# Altium schematic sheet IMX7S_DEBUG.SchDoc
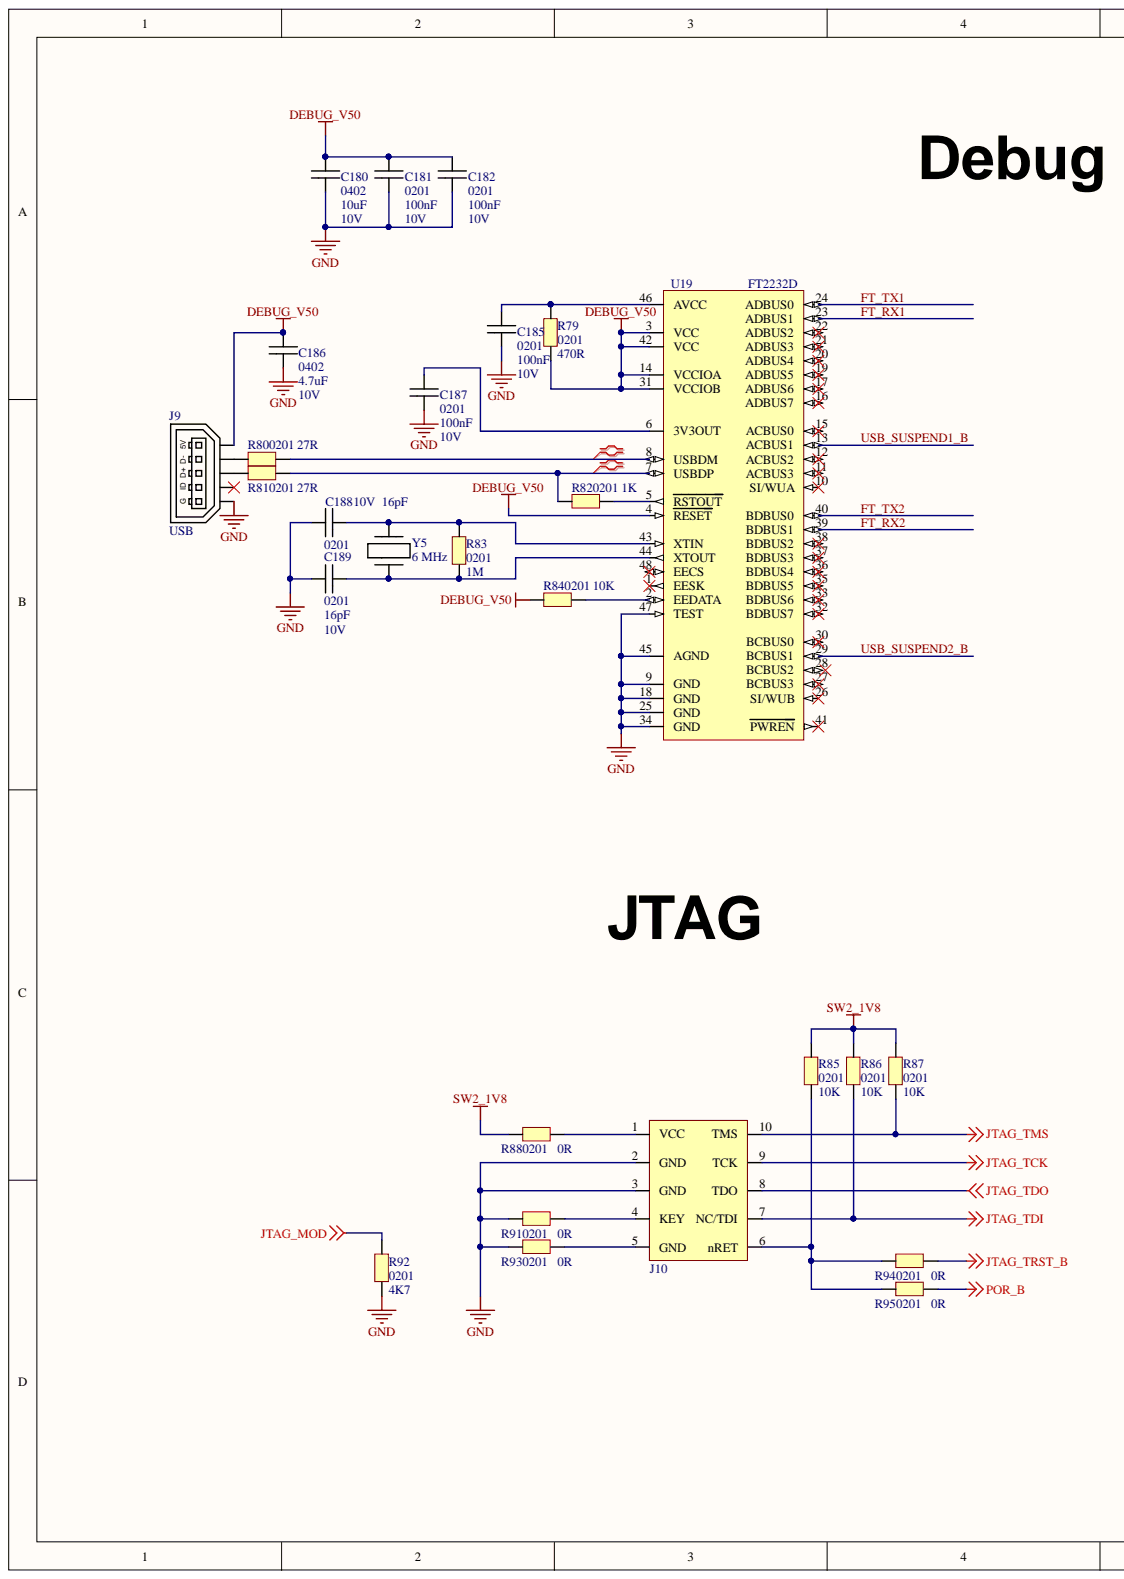
[diagram p0: IMX7S_DEBUG.SchDoc, left]
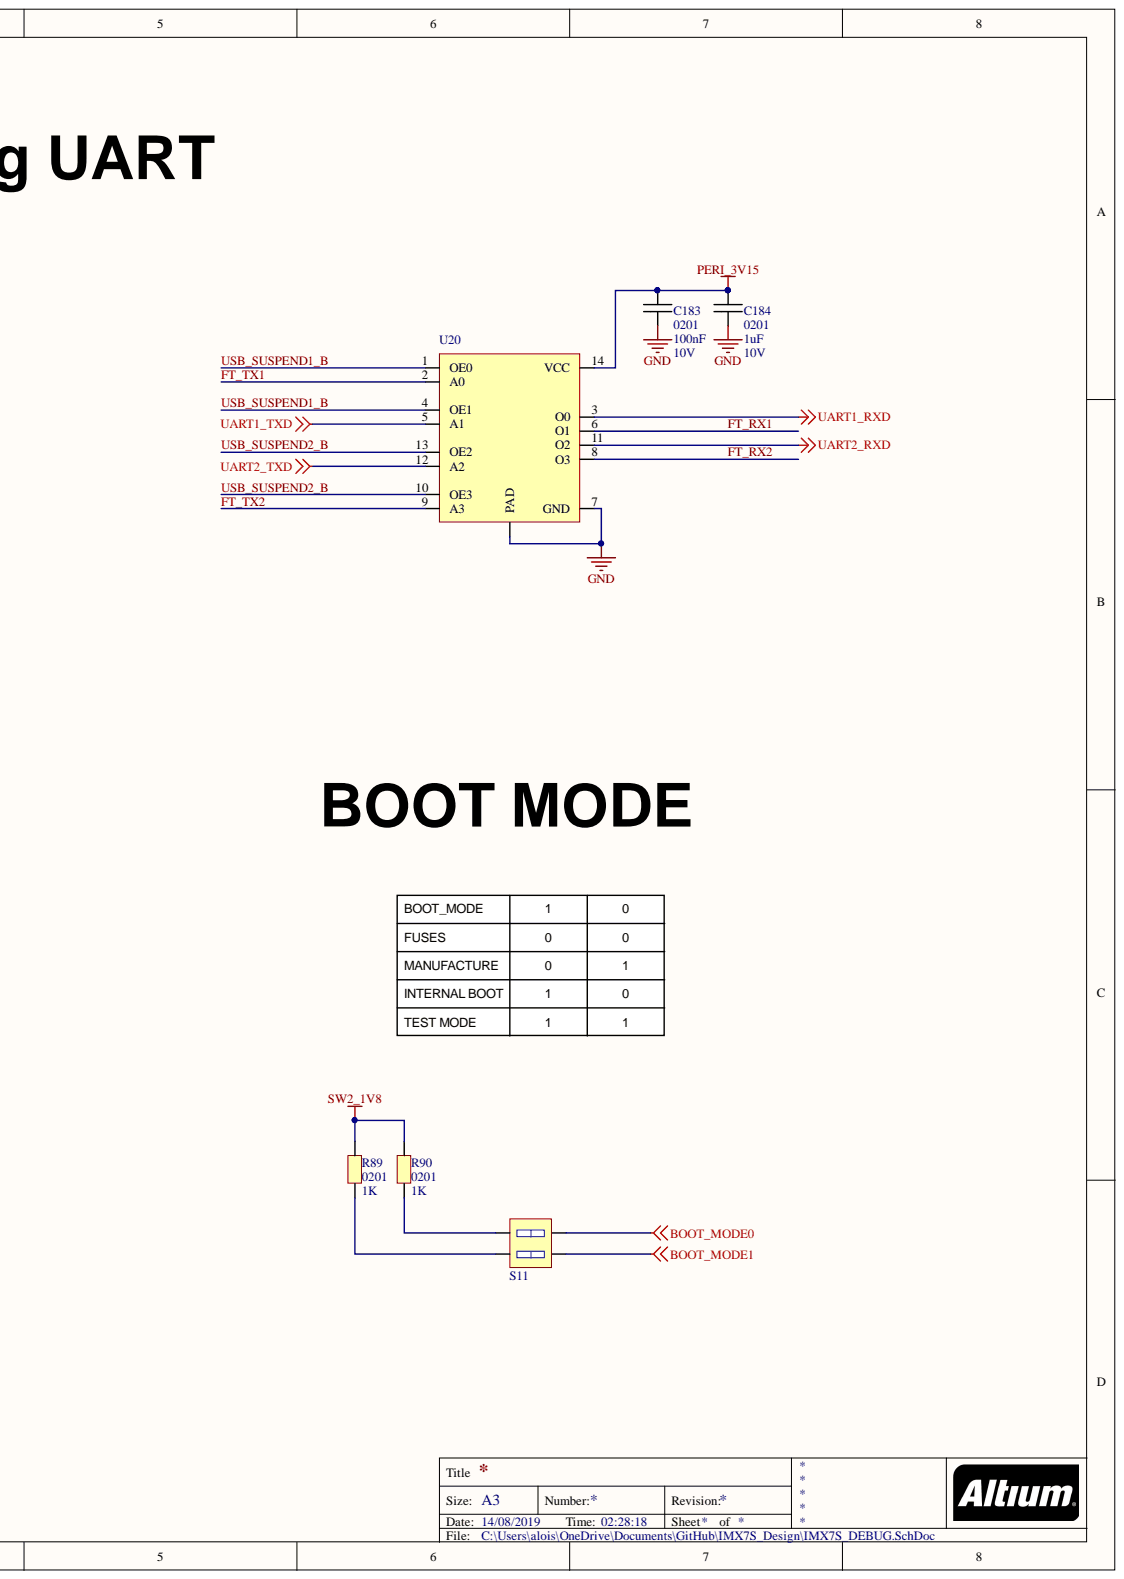
[diagram p1: IMX7S_DEBUG.SchDoc, right]

## Parts
C180  value 10uF  PACKAGE: 0402  VOLTAGE: 10V  [C0402]  (hidden comment: C0402)
C181  value 100nF  PACKAGE: 0201  VOLTAGE: 10V  [C0201]  (hidden comment: C0201)
C182  value 100nF  PACKAGE: 0201  VOLTAGE: 10V  [C0201]  (hidden comment: C0201)
C183  value 100nF  PACKAGE: 0201  VOLTAGE: 10V  [C0201]  (hidden comment: C0201)
C184  value 1uF  PACKAGE: 0201  VOLTAGE: 10V  [C0201]  (hidden comment: C0201)
C185  value 100nF  PACKAGE: 0201  [C0201]  (hidden comment: C0201)
C186  value 4.7uF  PACKAGE: 0402  VOLTAGE: 10V  [C0402]  (hidden comment: C0402)
C187  value 100nF  PACKAGE: 0201  VOLTAGE: 10V  [C0201]  (hidden comment: C0201)
C188  value 16pF  PACKAGE: 0201  VOLTAGE: 10V  [C0201]  (hidden comment: C0201)
C189  value 16pF  PACKAGE: 0201  VOLTAGE: 10V  [C0201]  (hidden comment: C0201)
J9  USB  [MICRO_USB]
J10  CONN_JTAG  [JTAG_CONN]  (value not drawn)
R79  R0201  value 470R  PACKAGE: 0201  [R0201]
R80  value 27R  PACKAGE: 0201  [R0201]  (hidden comment: R0201)
R81  value 27R  PACKAGE: 0201  [R0201]  (hidden comment: R0201)
R82  value 1K  PACKAGE: 0201  [R0201]  (hidden comment: R0201)
R83  value 1M  PACKAGE: 0201  [R0201]  (hidden comment: R0201)
R84  value 10K  PACKAGE: 0201  [R0201]  (hidden comment: R0201)
R85  value 10K  PACKAGE: 0201  [R0201]  (hidden comment: R0201)
R86  value 10K  PACKAGE: 0201  [R0201]  (hidden comment: R0201)
R87  value 10K  PACKAGE: 0201  [R0201]  (hidden comment: R0201)
R88  value 0R  PACKAGE: 0201  [R0201]  (hidden comment: R0201)
R89  value 1K  PACKAGE: 0201  [R0201]  (hidden comment: R0201)
R90  value 1K  PACKAGE: 0201  [R0201]  (hidden comment: R0201)
R91  value 0R  PACKAGE: 0201  [R0201]  (hidden comment: R0201)
R92  value 4K7  PACKAGE: 0201  [R0201]  (hidden comment: R0201)
R93  value 0R  PACKAGE: 0201  [R0201]  (hidden comment: R0201)
R94  value 0R  PACKAGE: 0201  [R0201]  (hidden comment: R0201)
R95  value 0R  PACKAGE: 0201  [R0201]  (hidden comment: R0201)
S11  mikroswitch  [DIP_SWITCH]  (value not drawn)
U19  FT2232D  [LQFP48]
U20  74LCX126BQX  [DQFN14]  (value not drawn)
Y5  6 MHz  [HC49]

## Nets
BOOT_MODE0: S11.4
BOOT_MODE1: S11.3
DEBUG_V50: C180.2 C181.2 C182.2 C186.2 J9.1 R79.1 R84.2 U19.3(VCC) U19.4(RESET) U19.14(VCCIOA) U19.31(VCCIOB) U19.42(VCC)
GND: C180.1 C181.1 C182.1 C183.1 C184.1 C185.1 C186.1 C187.1 C188.2 C189.2 J9.5 J10.2(GND) J10.3(GND) R91.2 R92.2 R93.2 U19.9(GND) U19.18(GND) U19.25(GND) U19.34(GND) U19.45(AGND) U19.47(TEST) U20.0(PAD) U20.7(GND)
JTAG_MOD: R92.1
JTAG_TCK: J10.9(TCK)
JTAG_TDI: J10.7(NC/TDI) R86.2
JTAG_TDO: J10.8(TDO)
JTAG_TMS: J10.10(TMS) R87.2
JTAG_TRST_B: R94.1
PERI_3V15: C183.2 C184.2 U20.14(VCC)
POR_B: R95.1
SW2_1V8: R85.2 R86.1 R87.1 R88.2 R89.2 R90.2
UART1_RXD: U20.3(O0)
UART1_TXD: U20.5(A1)
UART2_RXD: U20.11(O2)
UART2_TXD: U20.12(A2)
FT_RX1: U19.23(ADBUS1) U20.6(O1)
FT_RX2: U19.39(BDBUS1) U20.8(O3)
FT_TX1: U19.24(ADBUS0) U20.2(A0)
FT_TX2: U19.40(BDBUS0) U20.9(A3)
USB_SUSPEND1_B: U19.13(ACBUS1) U20.1(OE0) U20.4(OE1)
USB_SUSPEND2_B: U19.29(BCBUS1) U20.10(OE3) U20.13(OE2)
NetC185_2: C185.2 R79.2 U19.46(AVCC)
NetC187_2: C187.2 U19.6(3V3OUT)
NetC188_1: C188.1 R83.1 U19.43(XTIN) Y5.2
NetC189_1: C189.1 R83.2 U19.44(XTOUT) Y5.1
NetJ9_2: J9.2 R80.2
NetJ9_3: J9.3 R81.2
NetJ10_1: J10.1(VCC) R88.1
NetJ10_4: J10.4(KEY) R91.1
NetJ10_5: J10.5(GND) R93.1
NetJ10_6: J10.6(nRET) R85.1 R94.2 R95.2
NetR80_1: R80.1 U19.8(USBDM)
NetR81_1: R81.1 R82.2 U19.7(USBDP)
NetR82_1: R82.1 U19.5(RSTOUT)
NetR84_1: R84.1 U19.2(EEDATA)
NetR89_1: R89.1 S11.2
NetR90_1: R90.1 S11.1

Not connected: J9 4; U19 1(EESK) 10(SI/WUA) 11(ACBUS3) 12(ACBUS2) 15(ACBUS0) 16(ADBUS7) 17(ADBUS6) 19(ADBUS5) 20(ADBUS4) 21(ADBUS3) 22(ADBUS2) 26(SI/WUB) 27(BCBUS3) 28(BCBUS2) 30(BCBUS0) 32(BDBUS7) 33(BDBUS6) 35(BDBUS5) 36(BDBUS4) 37(BDBUS3) 38(BDBUS2) 41(PWREN) 48(EECS)

## Library data of the parts
C185 = C0201: VALUE: 100nF; VOLTAGE: 10V; Component Kind: Standard; Library Name: Schlib_IMX7.SchLib
J9 = MICRO_USB: Component Kind: Standard; Library Name: Schlib_IMX7.SchLib
R79-R95 = R0201: VOLTAGE: 0V; Component Kind: Standard; Library Name: Schlib_IMX7.SchLib
S11 = mikroswitch: Manufacturer 1: TE Connectivity; Manufacturer Part Number 1: 1571983-1; Actuator Length: 0mm; Actuator Material: Polymer; Actuator Type: Standard; Contact Current Rating: 100mA; Contact Resistance: 100mR; Electrical Life: 1000Cycles; Max Current Rating: 25mA; Max Voltage Rating (DC): 24V; Number of Poles: 2; Pitch: 1.27mm; Sealable: Yes (With); Switching Capacity: 400mW; Test Voltage: 300V; Throw Configuration: SPST; Voltage Rating (DC): 50V; Washable: Yes; Component Kind: Standard; ComponentLink1Description: https://datasheet.ciiva.com/29119/701643-da-01-en-dip-schalter-2pol-gdh02s04-29119728.pdf?src-supplier=Conrad; ComponentLink1URL: https://datasheet.ciiva.com/29119/701643-da-01-en-dip-schalter-2pol-gdh02s04-29119728.pdf?src-supplier=Conrad; ComponentLink2Description: https://datasheet.ciiva.com/16361/eng-cs-1308111-1-switches-core-program-catalog-0308-16361994.pdf?src-supplier=Future+Electronics; ComponentLink2URL: https://datasheet.ciiva.com/16361/eng-cs-1308111-1-switches-core-program-catalog-0308-16361994.pdf?src-supplier=Future+Electronics; ComponentLink3Description: https://datasheet.ciiva.com/30134/eng-cd-1571983-b-30134091.pdf?src-supplier=Onlinecomponents.com; ComponentLink3URL: https://datasheet.ciiva.com/30134/eng-cd-1571983-b-30134091.pdf?src-supplier=Onlinecomponents.com; ComponentLink4Description: https://datasheet.ciiva.com/15184/getdatasheetpartid-237177-15184941.pdf?src-supplier=Verical; ComponentLink4URL: https://datasheet.ciiva.com/15184/getdatasheetpartid-237177-15184941.pdf?src-supplier=Verical; ComponentLink5Description: https://datasheet.ciiva.com/25890/eng-cs-1308111-1-switches-core-program-catalog-0308-25890601.pdf?src-supplier=Avnet+Europe; ComponentLink5URL: https://datasheet.ciiva.com/25890/eng-cs-1308111-1-switches-core-program-catalog-0308-25890601.pdf?src-supplier=Avnet+Europe; Contact Material: Copper Alloy; Contact Plating: Gold; Depth: 8.13mm; ELV: Compliant; Flammability Rating: UL94 V-0; Height: 7.31mm; Housing Color: Black; Housing Material: Polyphenylene; Lead Free: Lead Free; Length: 0.144inch; Library Name: Schlib_IMX7.SchLib; Max Operating Temperature: 85°C; Min Operating Temperature: -30°C; Mount: Surface Mount; Number of Pins: 2; Number of Positions: 2; Orientation: Straight; Package Quantity: 125; Packaging: Tape and Reel; Pricing 1: 1=1,34000, 50=1,30000, 100=1,17000, 500=0,99600, 1000=0,77700 (EUR); Radiation Hardening: No; RoHS: Yes; Stock 1: 3281; Supplier 1: Farnell; Supplier Part Number 1: 1123952; Terminal Pitch: 1.27mm; Termination: Gull Wing; Type: Switch; Width: 8.13mm
U19 = FT2232D: USB Full Speed to Dual Channel Serial UART/FIFO/JTAG/SPI/I2C IC - LQFP-48; MF: FTDI,; MP: FT2232D-REEL; Component Kind: Standard; Digi-Key_Part_Number: 768-1010-1-ND; Digi-Key_Purchase_URL: https://www.digikey.com/product-detail/en/ftdi-future-technology-devices-international-ltd/FT2232D-REEL/768-1010-1-ND/1836405?utm_source=snapeda&utm_medium=aggregator&utm_campaign=symbol; Library Name: Schlib_IMX7.SchLib; Package: LQFP-48 FTDI; SnapEDA_Link: https://www.snapeda.com/parts/FT2232D-REEL/FTDI%2C%20Future%20Technology%20Devices%20International%20Ltd/view-part/?ref=snap
Y5 = QUARTZ_6MHz: Component Kind: Standard; Library Name: Schlib_IMX7.SchLib; Supplier 1: Farnell; Supplier Part Number 1: 2449403
C180 C186 = C0402: Component Kind: Standard; Library Name: Schlib_IMX7.SchLib
C181-C184 C187-C189 = C0201: Component Kind: Standard; Library Name: Schlib_IMX7.SchLib
J10 = CONN_JTAG: Component Kind: Standard; Library Name: Schlib_IMX7.SchLib; Supplier 1: Farnell; Supplier Part Number 1: 2112432
U20 = 74LCX126BQX: Component Kind: Standard; Library Name: Schlib_IMX7.SchLib
